# Altium schematic sheet GammaMRICAB_2 - Ethernet Conn.SchDoc
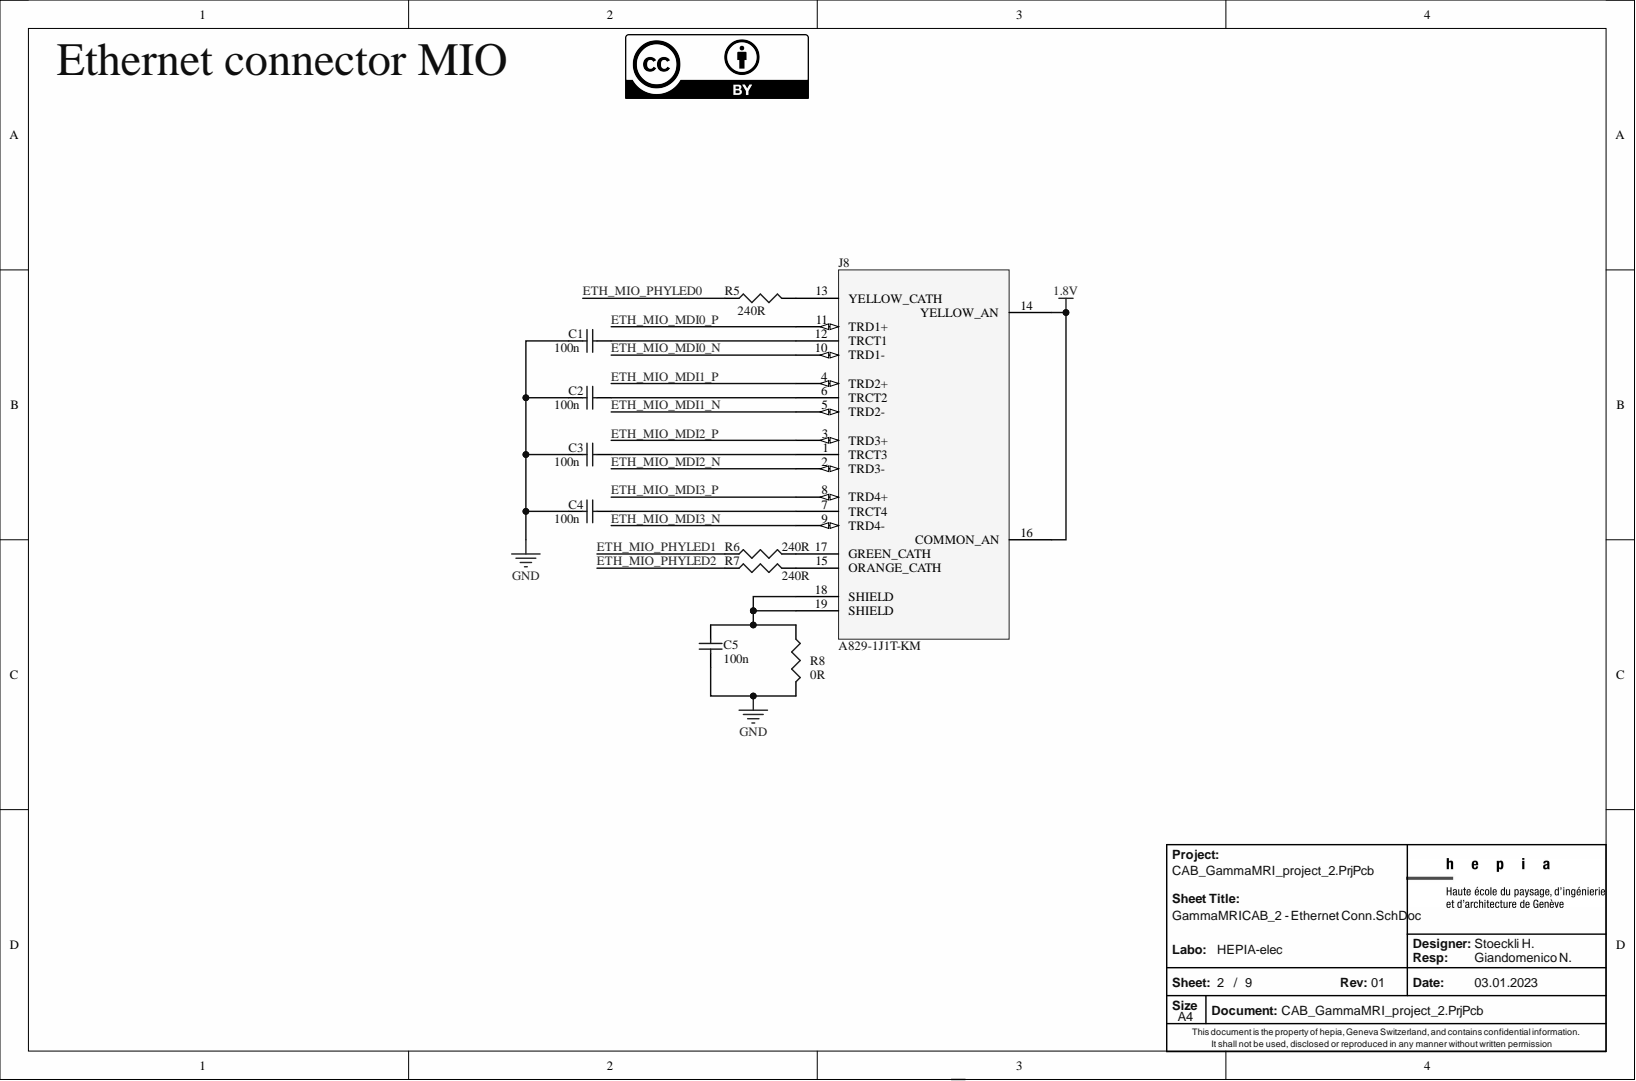
[diagram p0: GammaMRICAB_2 - Ethernet Conn.SchDoc]

## Parts
C1  100n  [0402]
C2  100n  [0402]
C3  100n  [0402]
C4  100n  [0402]
C5  100n  [0402]
J8  ‎A829-1J1T-KM‎  [BELS-L829-1J1T-43_V]
R5  240R  [0402]
R6  240R  [0402]
R7  240R  [0402]
R8  0R  [0402]

## Nets
1.8V: J8.14(YELLOW_AN) J8.16(COMMON_AN)
GND: C1.1 C2.1 C3.1 C4.1 C5.2 R8.2
ETH_MIO_MDI0_N: J8.10(TRD1-)
ETH_MIO_MDI0_P: J8.11(TRD1+)
ETH_MIO_MDI1_N: J8.5(TRD2-)
ETH_MIO_MDI1_P: J8.4(TRD2+)
ETH_MIO_MDI2_N: J8.2(TRD3-)
ETH_MIO_MDI2_P: J8.3(TRD3+)
ETH_MIO_MDI3_N: J8.9(TRD4-)
ETH_MIO_MDI3_P: J8.8(TRD4+)
ETH_MIO_PHYLED0: R5.1
ETH_MIO_PHYLED1: R6.1
ETH_MIO_PHYLED2: R7.1
NetC1_2: C1.2 J8.12(TRCT1)
NetC2_2: C2.2 J8.6(TRCT2)
NetC3_2: C3.2 J8.1(TRCT3)
NetC4_2: C4.2 J8.7(TRCT4)
NetC5_1: C5.1 J8.18(SHIELD) J8.19(SHIELD) R8.1
NetJ8_13: J8.13(YELLOW_CATH) R5.2
NetJ8_15: J8.15(ORANGE_CATH) R7.2
NetJ8_17: J8.17(GREEN_CATH) R6.2

## Library data of the parts
C1-C5 = Cap: Capacitor 100nF C0402 X5R 6.3V; Component Kind: Standard; Library Name: Miscellaneous Devices.IntLib; Signal Integrity: Cap; Simulation: CAP; Supplier1: Digikey; Supplier1PN1: 490-6287-6-ND
J8 = BELFUSE L829-1J1T-43: CONN MAGJACK 1PORT 1000 BASE-TX; Component Kind: Standard; Supplier1: Digikey; Supplier1PN1: ‎1419-1064-ND‎; Library Name: CAB_SCH_LIB.SchLib
R5-R7 = Res3: Resistor 240Ohm 1% 0402; Component Kind: Standard; Library Name: Miscellaneous Devices.IntLib; Signal Integrity: Res; Simulation: RESISTOR; Supplier1: Digikey; Supplier1PN1: 311-240LRCT-ND
R8 = Res3: Resistor 0Ohm 0402; Component Kind: Standard; Library Name: Miscellaneous Devices.IntLib; Signal Integrity: Res; Simulation: RESISTOR; Supplier1: Digikey; Supplier1PN1: RMCF0402ZT0R00CT-ND‎
